# Altium schematic sheet IO.sch
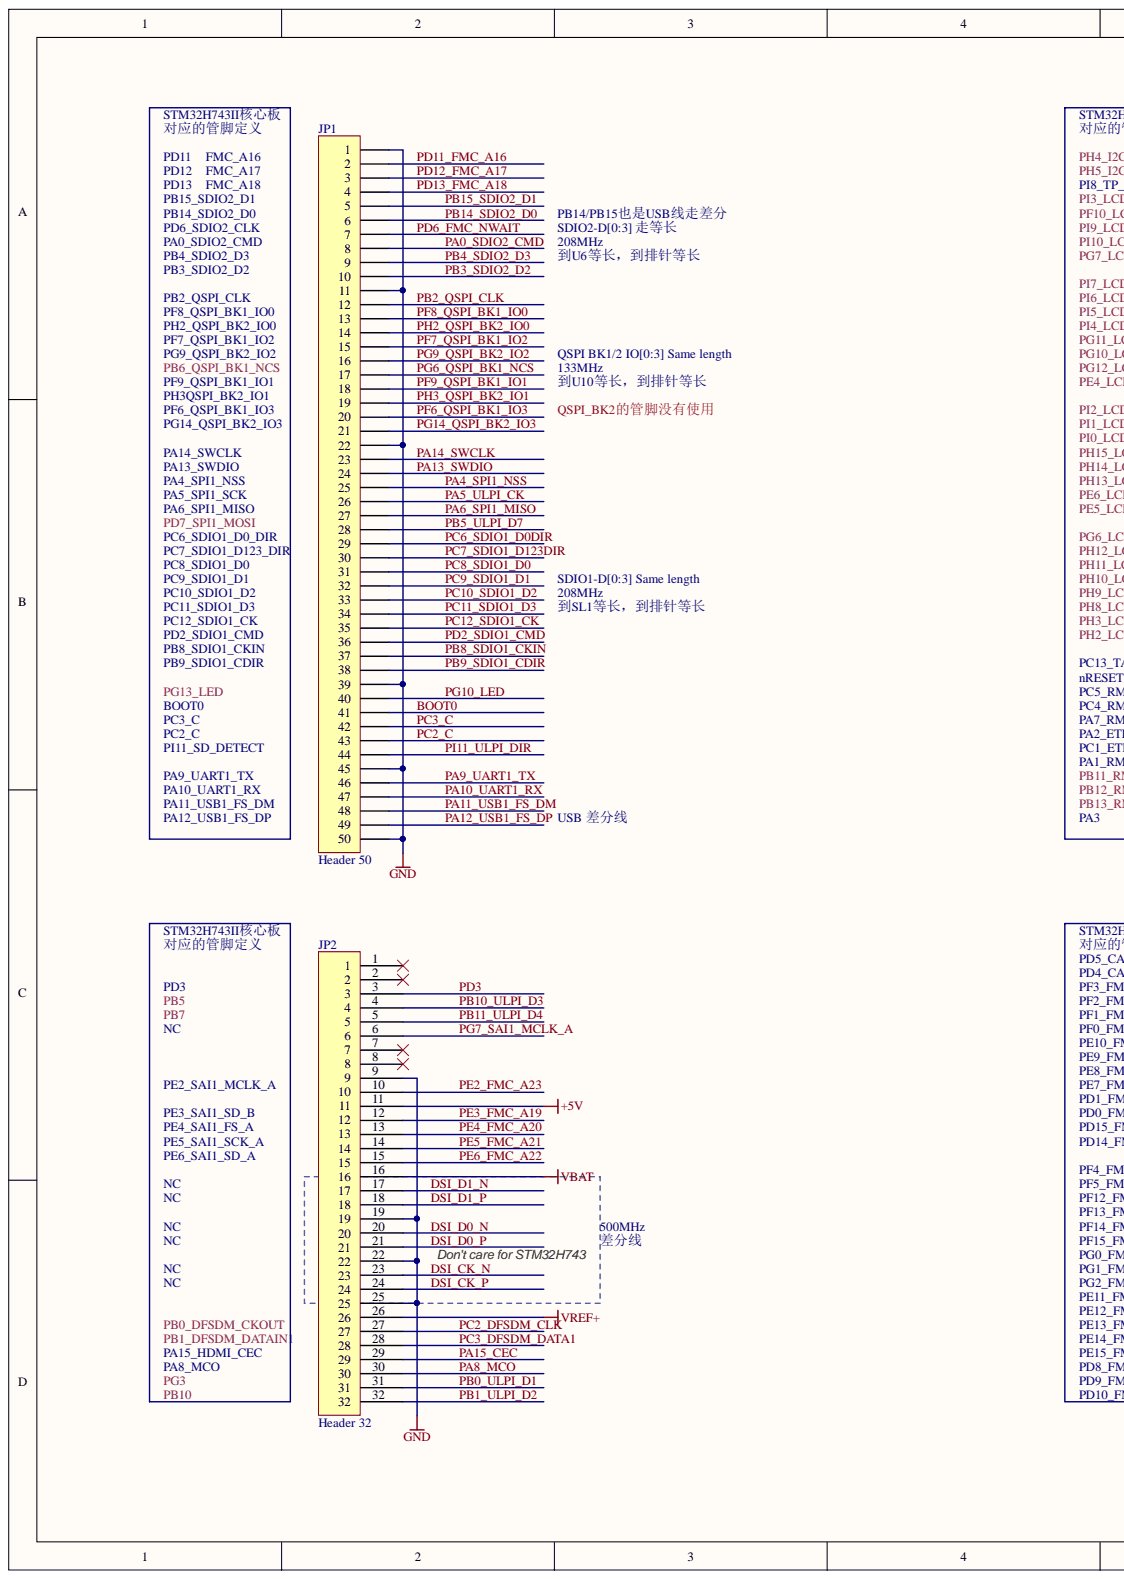
[diagram p0: IO.sch, left]
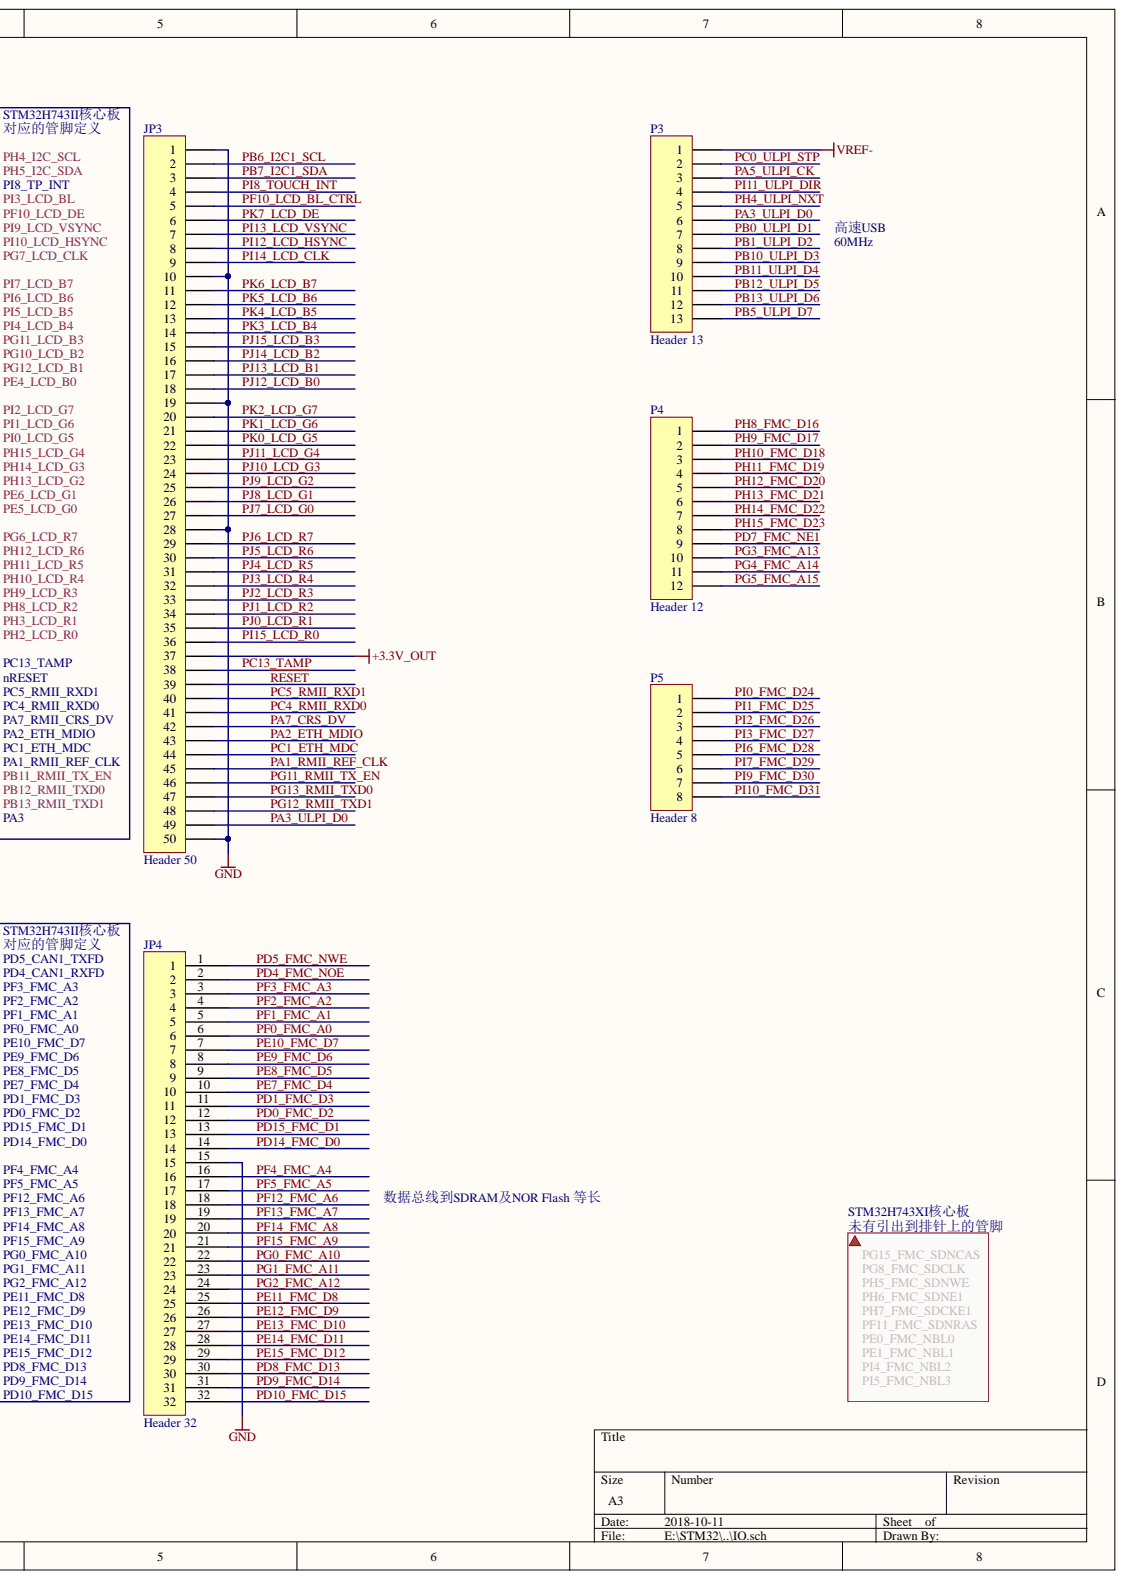
[diagram p1: IO.sch, right]

## Parts
JP1  Header 50  [SIP-50-1.27-SMD-R]
JP2  Header 32  [SIP-32-1.27-SMD-R]
JP3  Header 50  [SIP-50-1.27-SMD]
JP4  Header 32  [SIP-32-1.27-SMD]
P3  Header 13  [CN13SM]
P4  Header 12  [CN12SM]
P5  Header 8  [CN8SM]

## Nets
+3.3V_OUT: JP3.37
+5V: JP2.11
GND: JP1.1 JP1.11 JP1.22 JP1.39 JP1.45 JP1.50 JP2.9 JP2.19 JP2.22 JP2.25 JP3.1 JP3.10 JP3.19 JP3.28 JP3.50 JP4.15
VBAT: JP2.16
VREF+: JP2.26
VREF-: P3.1
BOOT0: JP1.41
DSI_CK_N: JP2.23
DSI_CK_P: JP2.24
DSI_D0_N: JP2.20
DSI_D0_P: JP2.21
DSI_D1_N: JP2.17
DSI_D1_P: JP2.18
PA0_SDIO2_CMD: JP1.8
PA1_RMII_REF_CLK: JP3.45
PA2_ETH_MDIO: JP3.43
PA3_ULPI_D0: JP3.49 P3.6
PA4_SPI1_NSS: JP1.25
PA5_ULPI_CK: JP1.26 P3.3
PA6_SPI1_MISO: JP1.27
PA7_CRS_DV: JP3.42
PA8_MCO: JP2.30
PA9_UART1_TX: JP1.46
PA10_UART1_RX: JP1.47
PA11_USB1_FS_DM: JP1.48
PA12_USB1_FS_DP: JP1.49
PA13_SWDIO: JP1.24
PA14_SWCLK: JP1.23
PA15_CEC: JP2.29
PB0_ULPI_D1: JP2.31 P3.7
PB1_ULPI_D2: JP2.32 P3.8
PB2_QSPI_CLK: JP1.12
PB3_SDIO2_D2: JP1.10
PB4_SDIO2_D3: JP1.9
PB5_ULPI_D7: JP1.28 P3.13
PB6_I2C1_SCL: JP3.2
PB7_I2C1_SDA: JP3.3
PB8_SDIO1_CKIN: JP1.37
PB9_SDIO1_CDIR: JP1.38
PB10_ULPI_D3: JP2.4 P3.9
PB11_ULPI_D4: JP2.5 P3.10
PB12_ULPI_D5: P3.11
PB13_ULPI_D6: P3.12
PB14_SDIO2_D0: JP1.6
PB15_SDIO2_D1: JP1.5
PC0_ULPI_STP: P3.2
PC1_ETH_MDC: JP3.44
PC2_C: JP1.43
PC2_DFSDM_CLK: JP2.27
PC3_C: JP1.42
PC3_DFSDM_DATA1: JP2.28
PC4_RMII_RXD0: JP3.41
PC5_RMII_RXD1: JP3.40
PC6_SDIO1_D0DIR: JP1.29
PC7_SDIO1_D123DIR: JP1.30
PC8_SDIO1_D0: JP1.31
PC9_SDIO1_D1: JP1.32
PC10_SDIO1_D2: JP1.33
PC11_SDIO1_D3: JP1.34
PC12_SDIO1_CK: JP1.35
PC13_TAMP: JP3.38
PD0_FMC_D2: JP4.12
PD1_FMC_D3: JP4.11
PD2_SDIO1_CMD: JP1.36
PD3: JP2.3
PD4_FMC_NOE: JP4.2
PD5_FMC_NWE: JP4.1
PD6_FMC_NWAIT: JP1.7
PD7_FMC_NE1: P4.9
PD8_FMC_D13: JP4.30
PD9_FMC_D14: JP4.31
PD10_FMC_D15: JP4.32
PD11_FMC_A16: JP1.2
PD12_FMC_A17: JP1.3
PD13_FMC_A18: JP1.4
PD14_FMC_D0: JP4.14
PD15_FMC_D1: JP4.13
PE2_FMC_A23: JP2.10
PE3_FMC_A19: JP2.12
PE4_FMC_A20: JP2.13
PE5_FMC_A21: JP2.14
PE6_FMC_A22: JP2.15
PE7_FMC_D4: JP4.10
PE8_FMC_D5: JP4.9
PE9_FMC_D6: JP4.8
PE10_FMC_D7: JP4.7
PE11_FMC_D8: JP4.25
PE12_FMC_D9: JP4.26
PE13_FMC_D10: JP4.27
PE14_FMC_D11: JP4.28
PE15_FMC_D12: JP4.29
PF0_FMC_A0: JP4.6
PF1_FMC_A1: JP4.5
PF2_FMC_A2: JP4.4
PF3_FMC_A3: JP4.3
PF4_FMC_A4: JP4.16
PF5_FMC_A5: JP4.17
PF6_QSPI_BK1_IO3: JP1.20
PF7_QSPI_BK1_IO2: JP1.15
PF8_QSPI_BK1_IO0: JP1.13
PF9_QSPI_BK1_IO1: JP1.18
PF10_LCD_BL_CTRL: JP3.5
PF12_FMC_A6: JP4.18
PF13_FMC_A7: JP4.19
PF14_FMC_A8: JP4.20
PF15_FMC_A9: JP4.21
PG0_FMC_A10: JP4.22
PG1_FMC_A11: JP4.23
PG2_FMC_A12: JP4.24
PG3_FMC_A13: P4.10
PG4_FMC_A14: P4.11
PG5_FMC_A15: P4.12
PG6_QSPI_BK1_NCS: JP1.17
PG7_SAI1_MCLK_A: JP2.6
PG9_QSPI_BK2_IO2: JP1.16
PG10_LED: JP1.40
PG11_RMII_TX_EN: JP3.46
PG12_RMII_TXD1: JP3.48
PG13_RMII_TXD0: JP3.47
PG14_QSPI_BK2_IO3: JP1.21
PH2_QSPI_BK2_IO0: JP1.14
PH3_QSPI_BK2_IO1: JP1.19
PH4_ULPI_NXT: P3.5
PH8_FMC_D16: P4.1
PH9_FMC_D17: P4.2
PH10_FMC_D18: P4.3
PH11_FMC_D19: P4.4
PH12_FMC_D20: P4.5
PH13_FMC_D21: P4.6
PH14_FMC_D22: P4.7
PH15_FMC_D23: P4.8
PI0_FMC_D24: P5.1
PI1_FMC_D25: P5.2
PI2_FMC_D26: P5.3
PI3_FMC_D27: P5.4
PI6_FMC_D28: P5.5
PI7_FMC_D29: P5.6
PI8_TOUCH_INT: JP3.4
PI9_FMC_D30: P5.7
PI10_FMC_D31: P5.8
PI11_ULPI_DIR: JP1.44 P3.4
PI12_LCD_HSYNC: JP3.8
PI13_LCD_VSYNC: JP3.7
PI14_LCD_CLK: JP3.9
PI15_LCD_R0: JP3.36
PJ0_LCD_R1: JP3.35
PJ1_LCD_R2: JP3.34
PJ2_LCD_R3: JP3.33
PJ3_LCD_R4: JP3.32
PJ4_LCD_R5: JP3.31
PJ5_LCD_R6: JP3.30
PJ6_LCD_R7: JP3.29
PJ7_LCD_G0: JP3.27
PJ8_LCD_G1: JP3.26
PJ9_LCD_G2: JP3.25
PJ10_LCD_G3: JP3.24
PJ11_LCD_G4: JP3.23
PJ12_LCD_B0: JP3.18
PJ13_LCD_B1: JP3.17
PJ14_LCD_B2: JP3.16
PJ15_LCD_B3: JP3.15
PK0_LCD_G5: JP3.22
PK1_LCD_G6: JP3.21
PK2_LCD_G7: JP3.20
PK3_LCD_B4: JP3.14
PK4_LCD_B5: JP3.13
PK5_LCD_B6: JP3.12
PK6_LCD_B7: JP3.11
PK7_LCD_DE: JP3.6
~{RESET}: JP3.39
N00011:
N00012:
N00013:
N00014:

Not connected: JP2 1 2 7 8

## Library data of the parts
JP1 JP3 = Header 50: Header, 50-Pin; Component Kind: Standard; LatestRevisionDate: 17-Jul-2002; LatestRevisionNote: Re-released for DXP Platform.; Library Name: MY-SCHLIB.SchLib; Signal Integrity: Connector; Publisher: Altium Limited
P3 = Header 13: Header, 13-Pin; Component Kind: Standard; LatestRevisionDate: 17-Jul-2002; LatestRevisionNote: Re-released for DXP Platform.; Library Name: Miscellaneous Connectors.IntLib; Signal Integrity: Connector; Publisher: Altium Limited
P4 = Header 12: Header, 12-Pin; Component Kind: Standard; LatestRevisionDate: 17-Jul-2002; LatestRevisionNote: Re-released for DXP Platform.; Library Name: Miscellaneous Connectors.IntLib; Signal Integrity: Connector; Publisher: Altium Limited
P5 = Header 8: Header, 8-Pin; Component Kind: Standard; LatestRevisionDate: 17-Jul-2002; LatestRevisionNote: Re-released for DXP Platform.; Library Name: Miscellaneous Connectors.IntLib; Signal Integrity: Connector; Publisher: Altium Limited
JP2 JP4 = Header 32: Component Kind: Standard; Library Name: MY-SCHLIB.SchLib
